# Altium schematic sheet PowCarbinBoard_Connect.SchDoc
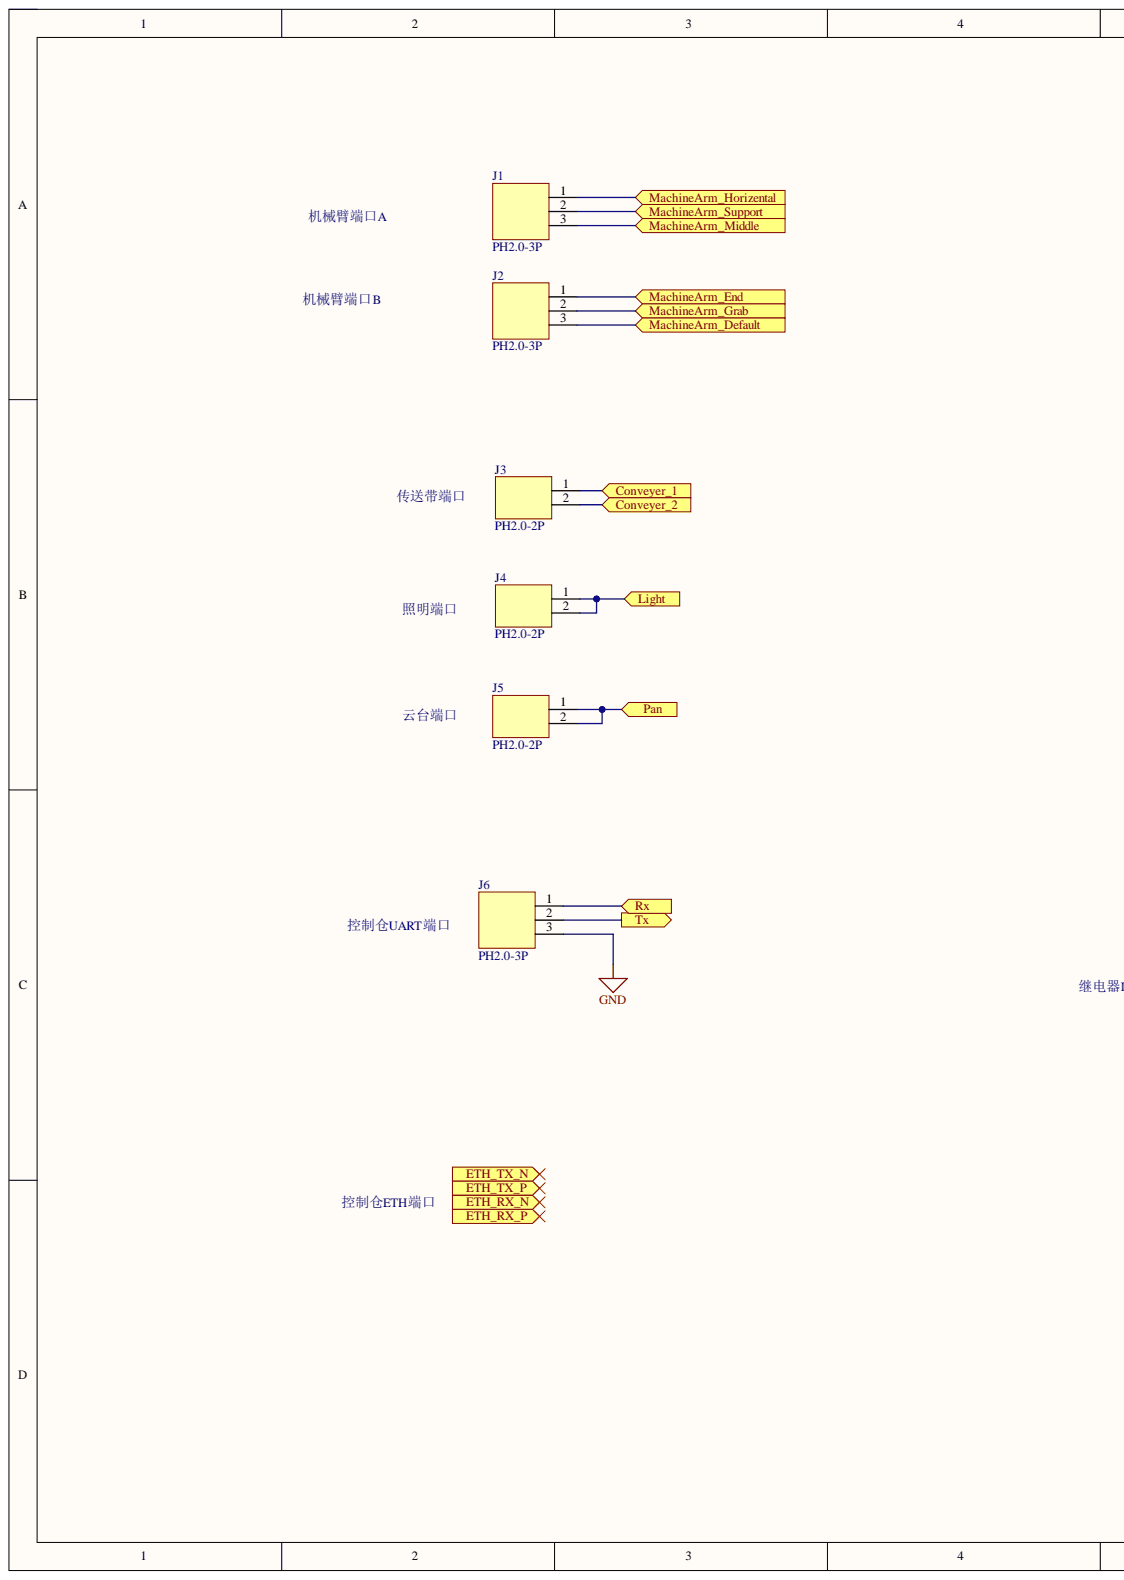
[diagram p0: PowCarbinBoard_Connect.SchDoc, left]
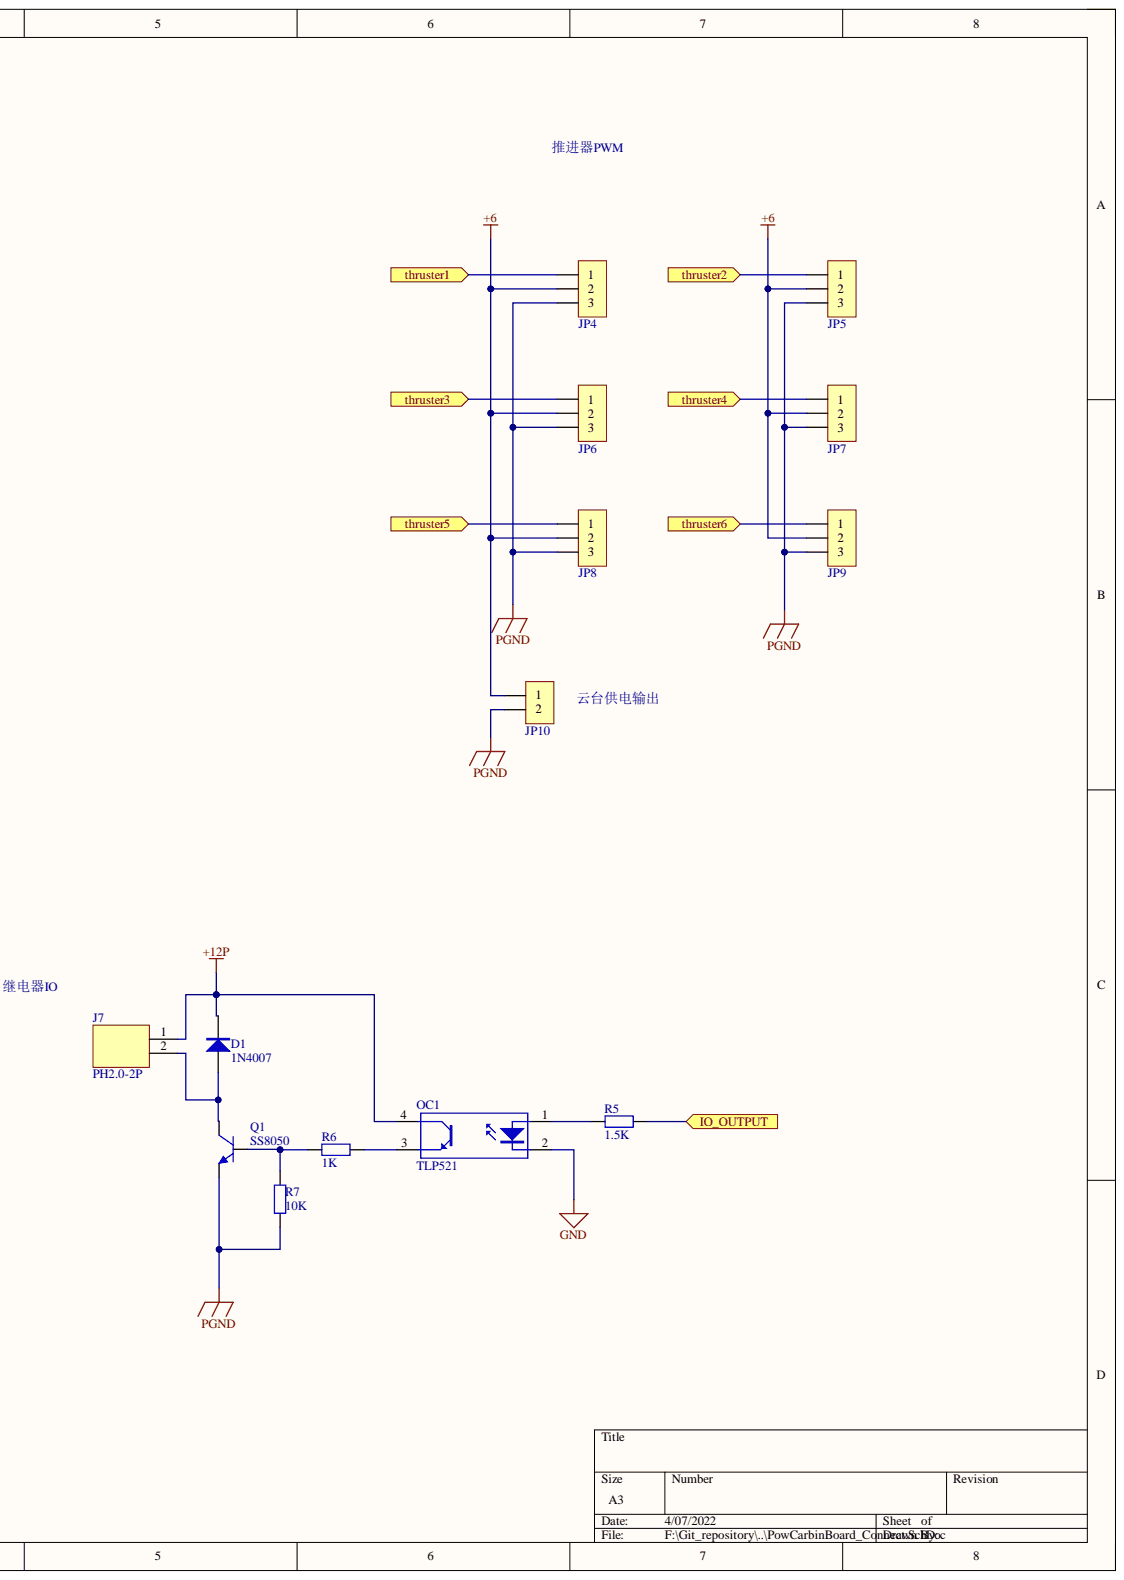
[diagram p1: PowCarbinBoard_Connect.SchDoc, right]

## Parts
D1  1N4007  [SOD-123]
J1  PH2.0-3P  [PH2.0-3P]
J2  PH2.0-3P  [PH2.0-3P]
J3  PH2.0-2P  [PH2.0-2P]
J4  PH2.0-2P  [PH2.0-2P]
J5  PH2.0-2P  [PH2.0-2P]
J6  PH2.0-3P  [PH2.0-3P]
J7  PH2.0-2P  [PH2.0-2P]
JP4  HDR-1X3  [HDR2.54-LI-3P]  (value not drawn)
JP5  HDR-1X3  [HDR2.54-LI-3P]  (value not drawn)
JP6  HDR-1X3  [HDR2.54-LI-3P]  (value not drawn)
JP7  HDR-1X3  [HDR2.54-LI-3P]  (value not drawn)
JP8  HDR-1X3  [HDR2.54-LI-3P]  (value not drawn)
JP9  HDR-1X3  [HDR2.54-LI-3P]  (value not drawn)
JP10  HDR-1X2  [KF128-5.08-2P]  (value not drawn)
OC1  TLP521  [DIP_TLP521]
Q1  SS8050  [SOT23-3N]
R5  1.5K  [0603_R]
R6  1K  [0603_R]
R7  10K  [0603_R]

## Ports
Conveyer_1, Conveyer_2, ETH_RX_N, ETH_RX_P, ETH_TX_N, ETH_TX_P, IO_OUTPUT, Light, MachineArm_Default, MachineArm_End, MachineArm_Grab, MachineArm_Horizental, MachineArm_Middle, MachineArm_Support, Pan, Rx, thruster1, thruster2, thruster3, thruster4, thruster5, thruster6, Tx

## Nets
+6: JP4.2 JP5.2 JP6.2 JP7.2 JP8.2 JP9.2 JP10.1
+12P: D1.2 J7.1 OC1.4
GND: J6.3 OC1.2
PGND: JP4.3 JP5.3 JP6.3 JP7.3 JP8.3 JP9.3 JP10.2 Q1.2 R7.1
Conveyer_1: J3.1 ; port Conveyer_1
Conveyer_2: J3.2 ; port Conveyer_2
IO_OUTPUT: R5.2 ; port IO_OUTPUT
Light: J4.1 J4.2 ; port Light
MachineArm_Default: J2.3 ; port MachineArm_Default
MachineArm_End: J2.1 ; port MachineArm_End
MachineArm_Grab: J2.2 ; port MachineArm_Grab
MachineArm_Horizental: J1.1 ; port MachineArm_Horizental
MachineArm_Middle: J1.3 ; port MachineArm_Middle
MachineArm_Support: J1.2 ; port MachineArm_Support
Pan: J5.1 J5.2 ; port Pan
Rx: J6.1 ; port Rx
thruster1: JP4.1 ; port thruster1
thruster2: JP5.1 ; port thruster2
thruster3: JP6.1 ; port thruster3
thruster4: JP7.1 ; port thruster4
thruster5: JP8.1 ; port thruster5
thruster6: JP9.1 ; port thruster6
Tx: J6.2 ; port Tx
NetD1_1: D1.1 J7.2 Q1.3
NetOC1_1: OC1.1 R5.1
NetOC1_3: OC1.3 R6.1
NetQ1_1: Q1.1 R6.2 R7.2
N00001: ; port ETH_RX_N
N00002: ; port ETH_TX_P
N00003: ; port ETH_RX_P
N00004: ; port ETH_TX_N

## Library data of the parts
D1 = 1N4007: 整流二极管; 版权归属: 源创客; BOM名称: 整流二极管; Component Kind: Standard; Library Name: 8.1   - 二极管-整流桥.SchLib; 学习资源: www.ooocke.com
JP4-JP9 = Header 3: 3P接插件; 版权归属: 源创客; BOM名称: 3P接插件; Component Kind: Standard; Library Name: 4.5   - 接插件-脚距2.54.SchLib; 学习资源: www.ooocke.com
JP10 = Header 2: 2P接插件; 版权归属: 源创客; BOM名称: 2P接插件; Component Kind: Standard; Library Name: 4.10 - 接插件-脚距5.08.SchLib; 学习资源: www.ooocke.com
OC1 = TLP521: 开关光耦; Component Kind: Standard; Library Name: 光电隔离器.IntLib
Q1 = 8050-SMD: 高频放大-NPN型; 属性: 40V 1.5A 1W 100MHZ; 版权归属: 源创客; BOM名称: 高频放大-NPN型; Component Kind: Standard; Library Name: 6.2   - 三极管.SchLib; 学习资源: www.ooocke.com
R5-R7 = 0603 10KΩ (1002) 1%: 贴片电阻; Component Kind: Standard; ComponentLink1Description: 供应商链接; ComponentLink1URL: http://www.szlcsc.com/product/details_26547.html; Library Name: Miscellaneous Devices LC.IntLib; Package: 0603; Supplier: LC; SuppliersPartNumber: C25804
J1 J2 J6 = PH2.0-3P: Component Kind: Standard; Library Name: PH.IntLib
J3-J5 J7 = PH2.0-2P: Component Kind: Standard; Library Name: PH.IntLib
